# Altium schematic sheet 07_Display&Button.SchDoc
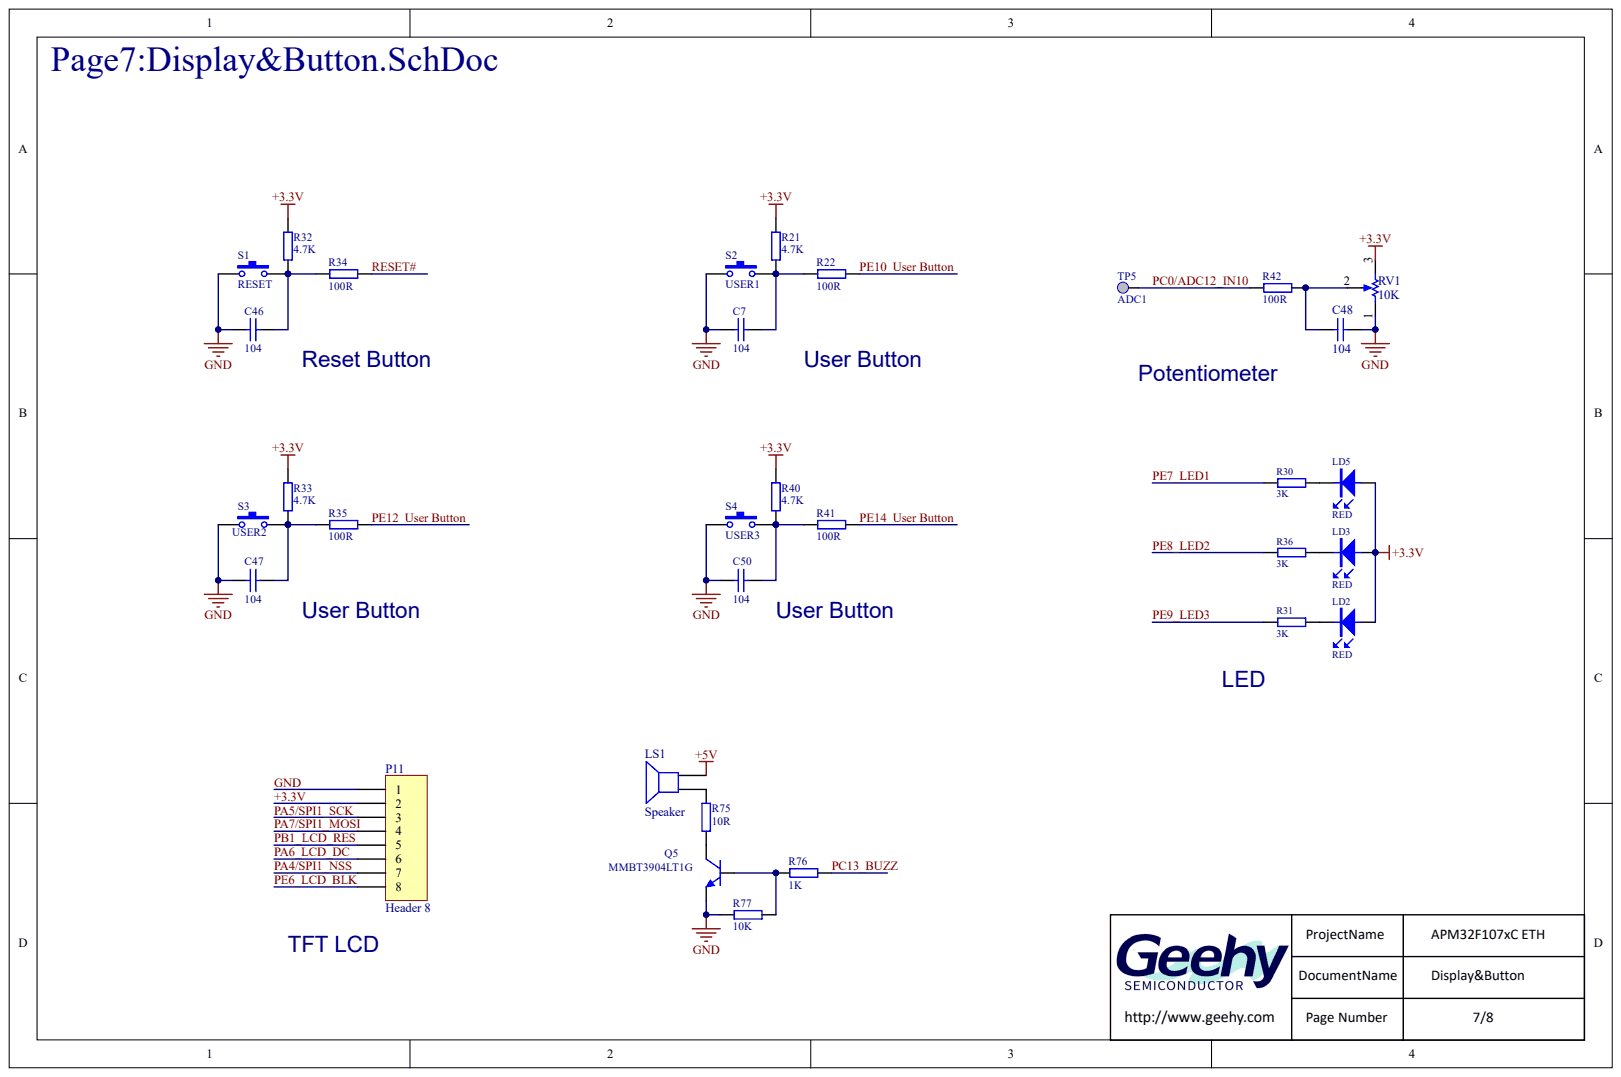
[diagram p0: 07_Display&Button.SchDoc]

## Parts
C7  104
C46  104
C47  104
C48  104
C50  104
H1  logo  (value not drawn)
H2  TFT  (value not drawn)
LD2  RED
LD3  RED
LD5  RED
LS1  Speaker
P11  Header 8
Q5  MMBT3904LT1G
R21  4.7K
R22  100R
R30  3K
R31  3K
R32  4.7K
R33  4.7K
R34  100R
R35  100R
R36  3K
R40  4.7K
R41  100R
R42  100R
R75  10R
R76  1K
R77  10K
RV1  10K
S1  RESET
S2  USER1
S3  USER2
S4  USER3
TP5  ADC1

## Nets
+5V: LS1.1
+3.3V: LD2.A LD3.A LD5.A P11.2 R21.2 R32.2 R33.2 R40.2 RV1.3
GND: C7.1 C46.1 C47.1 C48.2 C50.1 P11.1 Q5.2 R77.1 RV1.1 S1.2 S2.2 S3.2 S4.2
PA4/SPI1_NSS: P11.7
PA5/SPI1_SCK: P11.3
PA6_LCD_DC: P11.6
PA7/SPI1_MOSI: P11.4
PB1_LCD_RES: P11.5
PC0/ADC12_IN10: R42.2 TP5.1
PC13_BUZZ: R76.1
PE6_LCD_BLK: P11.8
PE7_LED1: R30.2
PE8_LED2: R36.2
PE9_LED3: R31.2
PE10_USER BUTTON: R22.1
PE12_USER BUTTON: R35.1
PE14_USER BUTTON: R41.1
RESET#: R34.1
NetC7_2: C7.2 R21.1 R22.2 S2.1
NetC46_2: C46.2 R32.1 R34.2 S1.1
NetC47_2: C47.2 R33.1 R35.2 S3.1
NetC48_1: C48.1 R42.1 RV1.2
NetC50_2: C50.2 R40.1 R41.2 S4.1
NetLD2_K: LD2.K R31.1
NetLD3_K: LD3.K R36.1
NetLD5_K: LD5.K R30.1
NetLS1_2: LS1.2 R75.1
NetQ5_1: Q5.1 R76.2 R77.2
NetQ5_3: Q5.3 R75.2

## Library data of the parts
C7 C46-C48 C50 = (no symbol name): Value: 100pF; Published: 8-Jun-2000; Publisher: Altium Limited
  C7 C46 C47 C50: LatestRevisionDate: 17-Jul-2002; LatestRevisionNote: Re-released for DXP Platform.; PackageReference: RAD-0.3; PackageDescription: Radial Cap, Thru-Hole; 2 Leads; 0.3 in Pin Spacing
  C48: Revision: July-2002: Re-released for DXP Platform.
H1 H2 LD2 LD3 LD5 LS1 P11 Q5 R21 R22 R30-R36 R40-R42 R75-R77 RV1 S1-S4 TP5 = (no symbol name)
  LS1: Published: 24-Mar-1999; LatestRevisionDate: 17-Jul-2002; LatestRevisionNote: Re-released for DXP Platform.; PackageReference: PIN2
  P11: LatestRevisionDate: 17-Jul-2002; LatestRevisionNote: Re-released for DXP Platform.
  LS1 P11: Publisher: Altium Limited
